# Altium schematic sheet driver_1ch.SchDoc
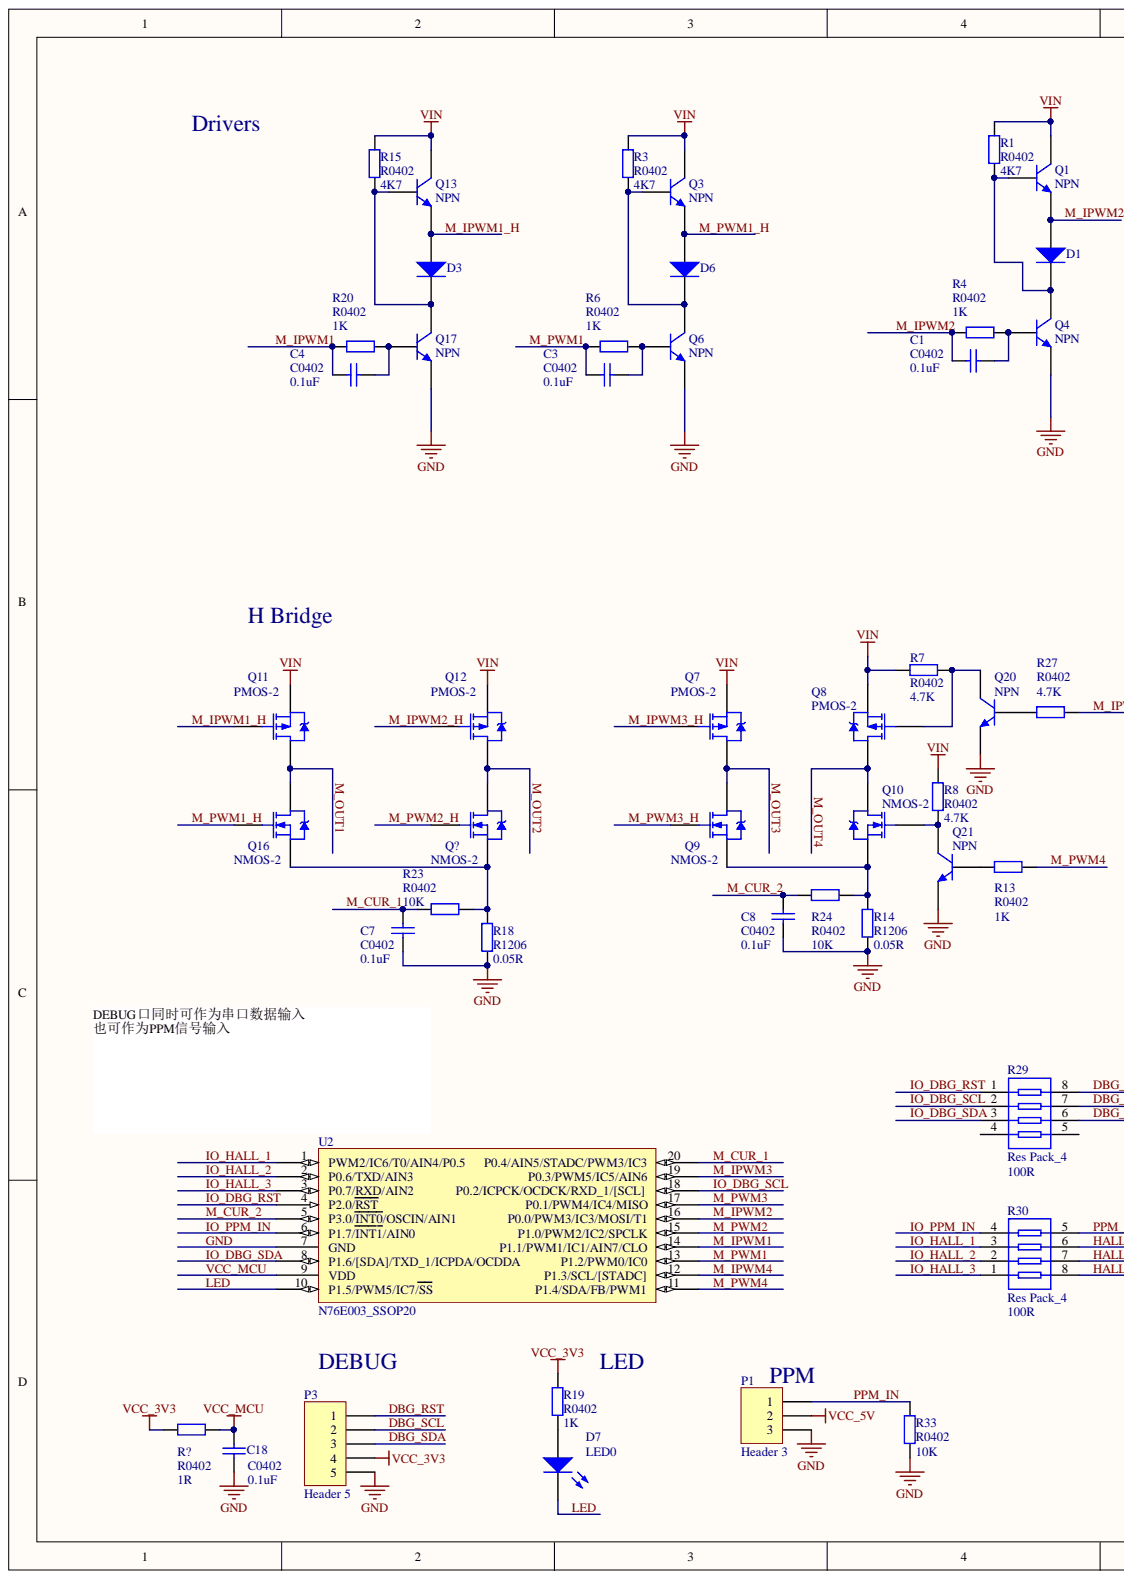
[diagram p0: driver_1ch.SchDoc, left]
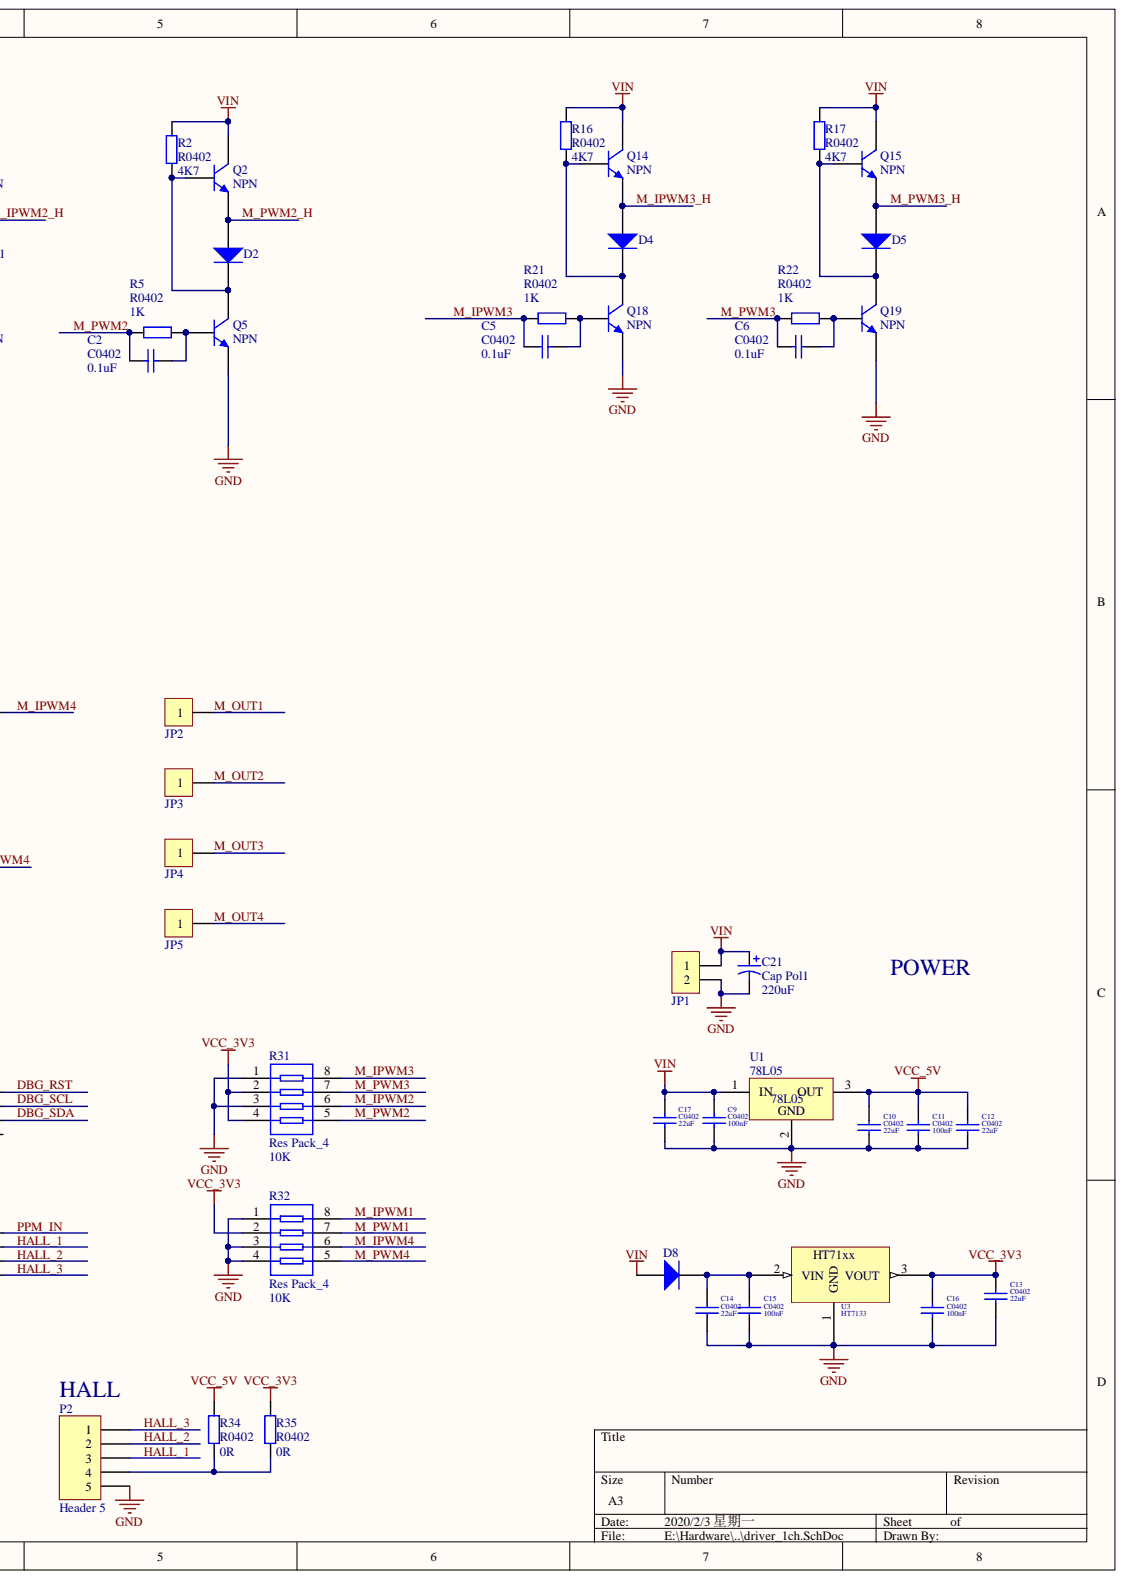
[diagram p1: driver_1ch.SchDoc, right]

## Parts
C1  C0402  value 0.1uF  [C 0402]
C2  C0402  value 0.1uF  [C 0402]
C3  C0402  value 0.1uF  [C 0402]
C4  C0402  value 0.1uF  [C 0402]
C5  C0402  value 0.1uF  [C 0402]
C6  C0402  value 0.1uF  [C 0402]
C7  C0402  value 0.1uF  [C 0402]
C8  C0402  value 0.1uF  [C 0402]
C9  C0402  value 100nF  [C 0402]
C10  C0402  value 22uF  [C 0402]
C11  C0402  value 100nF  [C 0402]
C12  C0402  value 22uF  [C 0402]
C13  C0402  value 22uF  [C 0402]
C14  C0402  value 22uF  [C 0402]
C15  C0402  value 100nF  [C 0402]
C16  C0402  value 100nF  [C 0402]
C17  C0402  value 22uF  [C 0402]
C18  C0402  value 0.1uF  [C 0402]
C21  Cap Pol1  value 220uF  [CT 10*8 - C]
D1  Diode 1N914  [SOD323]  (value not drawn)
D2  Diode 1N914  [SOD323]  (value not drawn)
D3  Diode 1N914  [SOD323]  (value not drawn)
D4  Diode 1N914  [SOD323]  (value not drawn)
D5  Diode 1N914  [SOD323]  (value not drawn)
D6  Diode 1N914  [SOD323]  (value not drawn)
D7  LED0  [LED 0603B]
D8  Diode 1N914  [SOD323]  (value not drawn)
JP1  HDR-1X2  [5.08-2P-HT508V]  (value not drawn)
JP2  HDR-1X1  [PAD_3*5mm]  (value not drawn)
JP3  HDR-1X1  [PAD_3*5mm]  (value not drawn)
JP4  HDR-1X1  [PAD_3*5mm]  (value not drawn)
JP5  HDR-1X1  [PAD_3*5mm]  (value not drawn)
P1  Header 3  [HDR1X3]
P2  Header 5  [HDR1X5]
P3  Header 5  [HDR1X5]
Q1  NPN  [SOT23-3M]
Q2  NPN  [SOT23-3M]
Q3  NPN  [SOT23-3M]
Q4  NPN  [SOT23-3M]
Q5  NPN  [SOT23-3M]
Q6  NPN  [SOT23-3M]
Q7  PMOS-2  [TO252]
Q8  PMOS-2  [TO252]
Q9  NMOS-2  [TO252]
Q10  NMOS-2  [TO252]
Q11  PMOS-2  [TO252]
Q12  PMOS-2  [TO252]
Q13  NPN  [SOT23-3M]
Q14  NPN  [SOT23-3M]
Q15  NPN  [SOT23-3M]
Q16  NMOS-2  [TO252]
Q17  NPN  [SOT23-3M]
Q18  NPN  [SOT23-3M]
Q19  NPN  [SOT23-3M]
Q20  NPN  [SOT23-3M]
Q21  NPN  [SOT23-3M]
Q?  NMOS-2  [TO252]
R1  R0402  value 4K7  [R 0402]
R2  R0402  value 4K7  [R 0402]
R3  R0402  value 4K7  [R 0402]
R4  R0402  value 1K  [R 0402]
R5  R0402  value 1K  [R 0402]
R6  R0402  value 1K  [R 0402]
R7  R0402  value 4.7K  [R 0402]
R8  R0402  value 4.7K  [R 0402]
R13  R0402  value 1K  [R 0402]
R14  R1206  value 0.05R  [R 1210]
R15  R0402  value 4K7  [R 0402]
R16  R0402  value 4K7  [R 0402]
R17  R0402  value 4K7  [R 0402]
R18  R1206  value 0.05R  [R 1210]
R19  R0402  value 1K  [R 0402]
R20  R0402  value 1K  [R 0402]
R21  R0402  value 1K  [R 0402]
R22  R0402  value 1K  [R 0402]
R23  R0402  value 10K  [R 0402]
R24  R0402  value 10K  [R 0402]
R27  R0402  value 4.7K  [R 0402]
R29  Res Pack_4  value 100R  [8P4R_0603]
R30  Res Pack_4  value 100R  [8P4R_0603]
R31  Res Pack_4  value 10K  [8P4R_0603]
R32  Res Pack_4  value 10K  [8P4R_0603]
R33  R0402  value 10K  [R 0402]
R34  R0402  value 0R  [R 0402]
R35  R0402  value 0R  [R 0402]
R?  R0402  value 1R  [R 0402]
U1  78L05  [TO252]
U2  N76E003_SSOP20  [SSOP20]
U3  HT7133  [SOT89_M]

## Nets
VCC_3V3: C13.2 C16.2 P3.4 R19.2 R31.2 R31.4 R32.2 R35.1 R?.1 U3.3
VCC_5V: C10.2 C11.2 C12.2 P1.2 R34.1 U1.3
VIN: C9.2 C17.2 C21.1 D8.1 JP1.1 Q1.3 Q2.3 Q3.3 Q7.3 Q8.3 Q11.3 Q12.3 Q13.3 Q14.3 Q15.3 R1.2 R2.2 R3.2 R7.1 R8.2 R15.2 R16.2 R17.2 U1.1
DBG_RST: P3.1 R29.8
DBG_SCL: P3.2 R29.7
DBG_SDA: P3.3 R29.6
GND: C7.1 C8.1 C9.1 C10.1 C11.1 C12.1 C13.1 C14.1 C15.1 C16.1 C17.1 C18.1 C21.2 JP1.2 P1.3 P2.5 P3.5 Q4.1 Q5.1 Q6.1 Q17.1 Q18.1 Q19.1 Q20.1 Q21.1 R14.1 R18.1 R31.1 R31.3 R32.1 R32.3 R32.4 R33.1 U1.2 U2.7(GND) U3.1
HALL_1: P2.3 R30.6
HALL_2: P2.2 R30.7
HALL_3: P2.1 R30.8
IO_DBG_RST: R29.1 U2.4(P2.0/RST)
IO_DBG_SCL: R29.2 U2.18(P0.2/ICPCK/OCDCK/RXD_1/[SCL])
IO_DBG_SDA: R29.3 U2.8(P1.6/[SDA]/TXD_1/ICPDA/OCDDA)
IO_HALL_1: R30.3 U2.1(PWM2/IC6/T0/AIN4/P0.5)
IO_HALL_2: R30.2 U2.2(P0.6/TXD/AIN3)
IO_HALL_3: R30.1 U2.3(P0.7/RXD/AIN2)
IO_PPM_IN: R30.4 U2.6(P1.7/INT1/AIN0)
LED: D7.2 U2.10(P1.5/PWM5/IC7/SS)
M_CUR_1: C7.2 R23.2 U2.20(P0.4/AIN5/STADC/PWM3/IC3)
M_CUR_2: C8.2 R24.2 U2.5(P3.0/INT0/OSCIN/AIN1)
M_IPWM1: C4.1 R20.1 R32.8 U2.14(P1.1/PWM1/IC1/AIN7/CLO)
M_IPWM1_H: D3.1 Q11.1 Q13.1
M_IPWM2: C1.1 R4.1 R31.6 U2.16(P0.0/PWM3/IC3/MOSI/T1)
M_IPWM2_H: D1.1 Q1.1 Q12.1
M_IPWM3: C5.1 R21.1 R31.8 U2.19(P0.3/PWM5/IC5/AIN6)
M_IPWM3_H: D4.1 Q7.1 Q14.1
M_IPWM4: R27.2 R32.6 U2.12(P1.3/SCL/[STADC])
M_OUT1: JP2.1 Q11.2 Q16.2
M_OUT2: JP3.1 Q12.2 Q?.2
M_OUT3: JP4.1 Q7.2 Q9.2
M_OUT4: JP5.1 Q8.2 Q10.2
M_PWM1: C3.1 R6.1 R32.7 U2.13(P1.2/PWM0/IC0)
M_PWM1_H: D6.1 Q3.1 Q16.1
M_PWM2: C2.1 R5.1 R31.5 U2.15(P1.0/PWM2/IC2/SPCLK)
M_PWM2_H: D2.1 Q2.1 Q?.1
M_PWM3: C6.1 R22.1 R31.7 U2.17(P0.1/PWM4/IC4/MISO)
M_PWM3_H: D5.1 Q9.1 Q15.1
M_PWM4: R13.1 R32.5 U2.11(P1.4/SDA/FB/PWM1)
PPM_IN: P1.1 R30.5 R33.2
VCC_MCU: C18.2 R?.2 U2.9(VDD)
NetC1_2: C1.2 Q4.2 R4.2
NetC2_2: C2.2 Q5.2 R5.2
NetC3_2: C3.2 Q6.2 R6.2
NetC4_2: C4.2 Q17.2 R20.2
NetC5_2: C5.2 Q18.2 R21.2
NetC6_2: C6.2 Q19.2 R22.2
NetC14_2: C14.2 C15.2 D8.2 U3.2
NetD1_2: D1.2 Q1.2 Q4.3 R1.1
NetD2_2: D2.2 Q2.2 Q5.3 R2.1
NetD3_2: D3.2 Q13.2 Q17.3 R15.1
NetD4_2: D4.2 Q14.2 Q18.3 R16.1
NetD5_2: D5.2 Q15.2 Q19.3 R17.1
NetD6_2: D6.2 Q3.2 Q6.3 R3.1
NetD7_1: D7.1 R19.1
NetP2_4: P2.4 R34.2 R35.2
NetQ8_1: Q8.1 Q20.3 R7.2
NetQ9_3: Q9.3 Q10.3 R14.2 R24.1
NetQ10_1: Q10.1 Q21.3 R8.1
NetQ16_3: Q16.3 Q?.3 R18.2 R23.1
NetQ20_2: Q20.2 R27.1
NetQ21_2: Q21.2 R13.2

Not connected: R29 4 5

## Library data of the parts
C1-C18 = Cap: Capacitor; Component Kind: Standard; LatestRevisionDate: 17-Jul-2002; LatestRevisionNote: Re-released for DXP Platform.; Library Name: Miscellaneous Devices.IntLib; PackageDescription: Radial Cap, Thru-Hole; 2 Leads; 0.3 in Pin Spacing; PackageReference: RAD-0.3; Published: 8-Jun-2000; Signal Integrity: Cap; Simulation: CAP; Publisher: Altium Limited
C21 = Cap Pol1: Polarized Capacitor (Radial); Component Kind: Standard; LatestRevisionDate: 17-Jul-2002; LatestRevisionNote: Re-released for DXP Platform.; Library Name: Miscellaneous Devices.IntLib; PackageDescription: Polarized Capacitor (Radial); 2 Leads; PackageReference: RB7.6-15; Published: 8-Jun-2000; Signal Integrity: Cap Pol; Simulation: CAP; Publisher: Altium Limited
D1-D6 D8 = Diode 1N914: High Conductance Fast Diode; Code_JEDEC: DO-204-AH; Component Kind: Standard; ComponentLink1Description: Manufacturer Link; ComponentLink1URL: http://www.fairchildsemi.com/; ComponentLink2Description: Datasheet; ComponentLink2URL: http://www.fairchildsemi.com/ds/1N%2F1N4448.pdf; DatasheetVersion: 1997; LatestRevisionDate: 17-Jul-2002; LatestRevisionNote: Re-released for DXP Platform.; Library Name: Miscellaneous Devices.IntLib; PackageDescription: Diode, Axial; Body 3.8 x 1.9 mm (LxDia. max), Lead Dia. 0.53 mm (max); PackageReference: DO-35; Published: 8-Jun-2000; Signal Integrity: 1N914; Simulation: 1N914; Publisher: Altium Limited
D7 = LED0: Typical INFRARED GaAs LED; Component Kind: Standard; LatestRevisionDate: 08-Jul-2005; LatestRevisionNote: Mech Layer 1 removed.; Library Name: Miscellaneous Devices.IntLib; PackageDescription: LED; 2 Leads; PackageReference: LED-0; Published: 8-Jun-2000; Simulation: LED0; Publisher: Altium Limited
JP1 = Header 2: 2P接插件; 版权归属: 源创客; BOM名称: 2P接插件; Component Kind: Standard; Library Name: 接插件.SchLib; 学习资源: www.ooocke.com
JP2-JP5 = Header 1: 1P接插件; 版权归属: 源创客; BOM名称: 1P接插件; Component Kind: Standard; Library Name: 接插件.SchLib; 学习资源: www.ooocke.com
P1 = Header 3: Header, 3-Pin; Component Kind: Standard; LatestRevisionDate: 17-Jul-2002; LatestRevisionNote: Re-released for DXP Platform.; Library Name: Miscellaneous Connectors.IntLib; Signal Integrity: Connector; Publisher: Altium Limited
P2 P3 = Header 5: Header, 5-Pin; Component Kind: Standard; LatestRevisionDate: 17-Jul-2002; LatestRevisionNote: Re-released for DXP Platform.; Library Name: Connectors.SCHLIB; Signal Integrity: Connector; Publisher: Altium Limited
Q1-Q6 Q13-Q15 Q17-Q21 = 2N3904: NPN General Purpose Amplifier; Component Kind: Standard; ComponentLink1Description: Manufacturer Link; ComponentLink1URL: http://www.fairchildsemi.com/; ComponentLink2Description: Datasheet; ComponentLink2URL: http://www.fairchildsemi.com/ds/2N%2F2N3904.pdf; DatasheetVersion: 1997; LatestRevisionDate: 15-Jan-2003; LatestRevisionNote: PCB Footprint 'BCY-W3/D4.7' replaced; Library Name: Miscellaneous Devices.IntLib; PackageDescription: TO, Flat Index; 3 In-Line, Axial Leads; Body Dia. 4.5mm; Leads 0.51 x 0.48 mm (max); PackageReference: TO-92A; PackageVersion: Sep-1998; Published: 8-Jun-2000; Signal Integrity: 2N3904; Simulation: 2N3904; Publisher: Altium Limited
Q7 Q8 Q11 Q12 = PMOS-2: P-Channel Power MOSFET; Note: Pin 4 not drawn and electrically connected to Pin 2.; Component Kind: Standard; ComponentLink1Description: Manufacturer Link; ComponentLink1URL: http://www.irf.com/; DatasheetVersion: PD-9.390D; LatestRevisionDate: 17-Jul-2002; LatestRevisionNote: Re-released for DXP Platform.; Library Name: Miscellaneous Devices.IntLib; PackageDescription: TO, Thru-Hole, Vertical, Heatsink Mounted; 3 In-Line Leads; Pitch 2.54 mm; PackageReference: TO-220AB; PackageVersion: Feb-1997; Published: 8-Jun-2000; Simulation: IRF9510; Publisher: Altium Limited
Q9 Q10 Q16 Q? = NMOS-2: N-Channel Power MOSFET; Note: Pin 2 is electrically connected to pin 4.; Component Kind: Standard; ComponentLink1Description: Manufacturer Link; ComponentLink1URL: http://www.irf.com/; DatasheetVersion: PD-9.814; LatestRevisionDate: 17-Jul-2002; LatestRevisionNote: Re-released for DXP Platform.; Library Name: Miscellaneous Devices.IntLib; PackageDescription: TO, Thru-Hole, Vertical, Heatsink Mounted; 3 In-Line Leads; Pitch 2.54 mm; PackageReference: TO-220AB; PackageVersion: Feb-1997; Published: 8-Jun-2000; Simulation: IRF1010; Publisher: Altium Limited
R1-R6 R8 R13-R24 R27 R33-R35 R? = Res2: Resistor; Component Kind: Standard; LatestRevisionDate: 17-Jul-2002; LatestRevisionNote: Re-released for DXP Platform.; Library Name: Miscellaneous Devices.IntLib; PackageDescription: Axial Device, Thru-Hole; 2 Leads; 0.4 in Pin Spacing; PackageReference: AXIAL-0.4; Published: 8-Jun-2000; Signal Integrity: Res; Simulation: RESISTOR; Publisher: Altium Limited
R7 = Res2: Resistor; Value: 4.7K; Component Kind: Standard; LatestRevisionDate: 17-Jul-2002; LatestRevisionNote: Re-released for DXP Platform.; Library Name: Miscellaneous Devices.IntLib; PackageDescription: Axial Device, Thru-Hole; 2 Leads; 0.4 in Pin Spacing; PackageReference: AXIAL-0.4; Published: 8-Jun-2000; Signal Integrity: Res; Simulation: RESISTOR; Publisher: Altium Limited
R29-R32 = Res Pack_4: Isolated Resistor Network; Code_IPC: SOP65P780-16; Code_JEDEC: MO-150-AC; Component Kind: Standard; LatestRevisionDate: 29-May-2009; LatestRevisionNote: IPC-7351 Footprint Added.; Library Name: MySchLib.SchLib; PackageDescription: 16-Pin Plastic Small Outline Package 0.65 mm Pitch; PackageReference: SSOP16; PackageVersion: Oct-2003; Published: 24-Mar-1999; Simulation: respack_8; Publisher: Altium Limited
U1 = 78L05: 3-Terminal Positive Regulators; Manufacturer: National Semiconductor; PartNumber: LM78L05ACZ; CompType: LDO IC; PackageTopMarking: NSXYTT*LM78L*05ACZ; PowerWise: N; Code_JEDEC: TO-226-AA; Component Kind: Standard; ComponentLink1Description: LM78L05 Datasheet; ComponentLink1URL: http://www.national.com/ds/LM/LM78L05.pdf; ComponentLink2Description: LM78L05 Web Page; ComponentLink2URL: http://www.national.com/pf/LM/LM78L05.html; ComponentLink3Description: Z03A Package Page; ComponentLink3URL: http://www.national.com/packaging/folders/z03a.html; DatasheetVersion: Apr-2006; DigiKeyPartNumber: LM78L05ACZNS-ND; PackageDescription: TO-92, Molded, 3 Lead; PackageReference: Z03A; PackageVersion: revG, Sep-2000; PinCount: 3; Published: 4-Dec-2008; RoHS: O; Library Name: EButterflyIntegratedLibrary.IntLib; Publisher: Altium Limited
U2 = N76E003_SSOP20: nuvoton mcu, 20pin; Component Kind: Standard; Library Name: MySchLib.SchLib
U3 = HT71xx: Value: 3.3V; Component Kind: Standard; Library Name: MySchLib.SchLib
